# Altium schematic sheet Schematic Prints("All Documents",Physical)
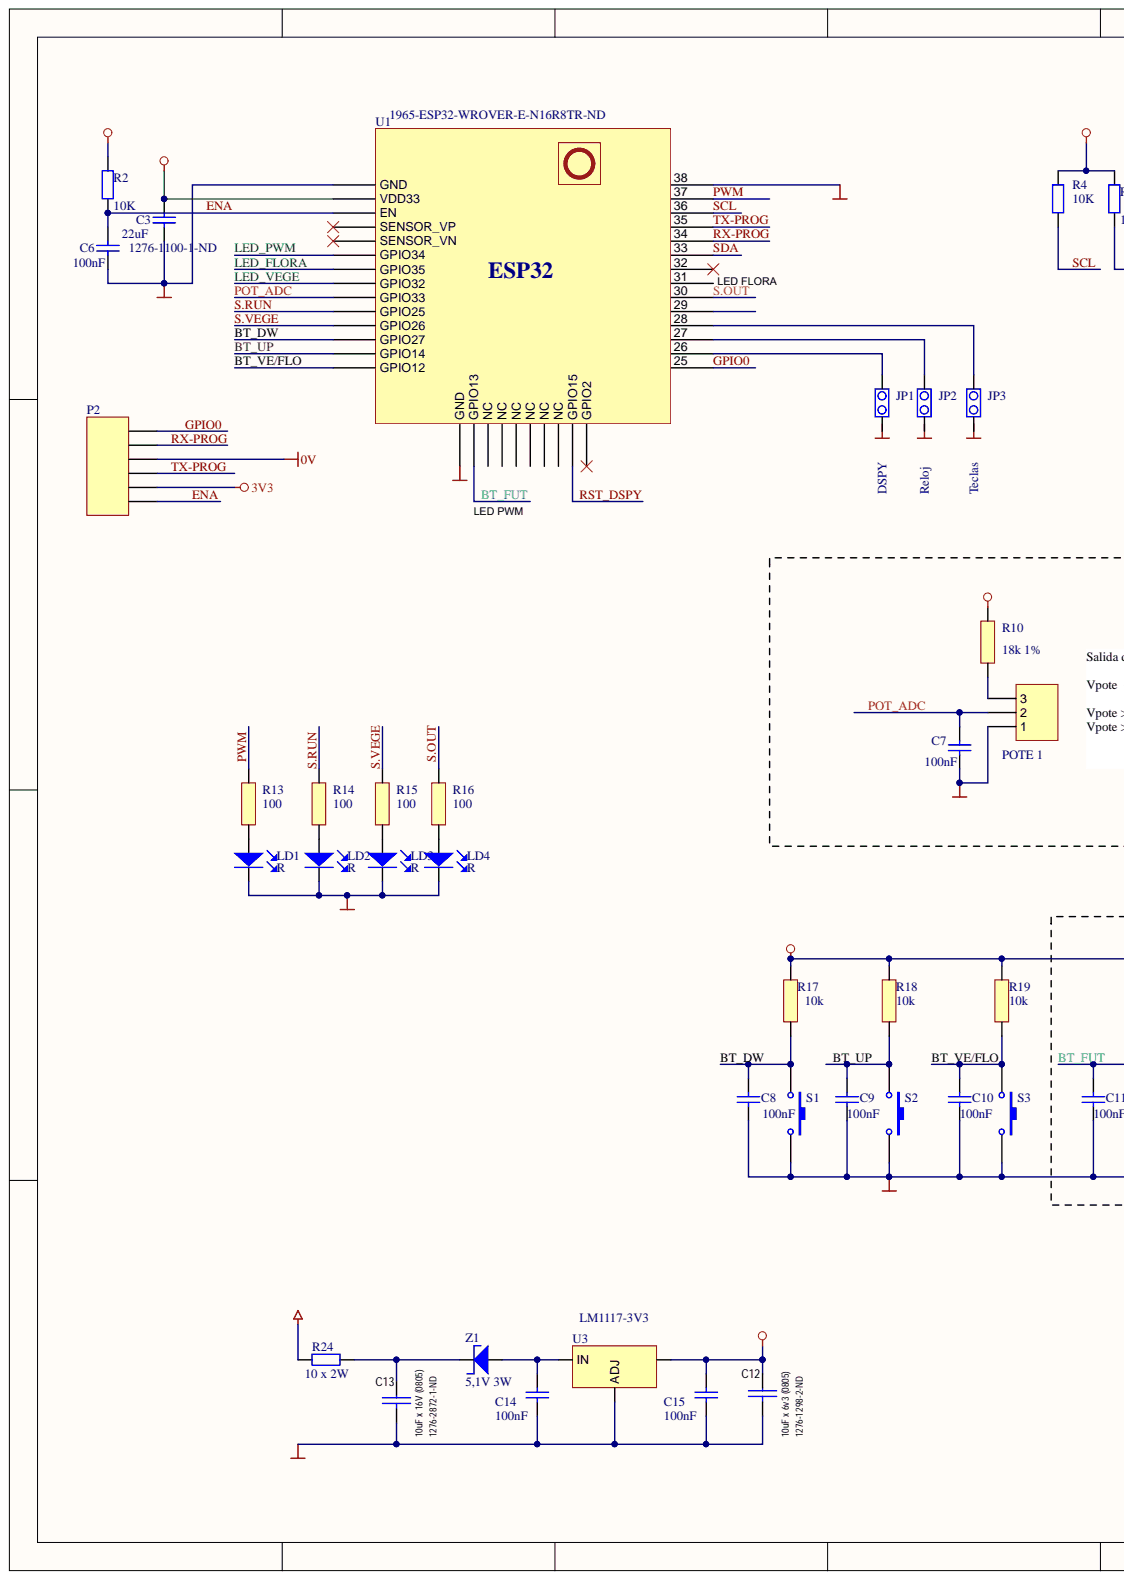
[diagram p0: Schematic Prints("All Documents",Physical), left]
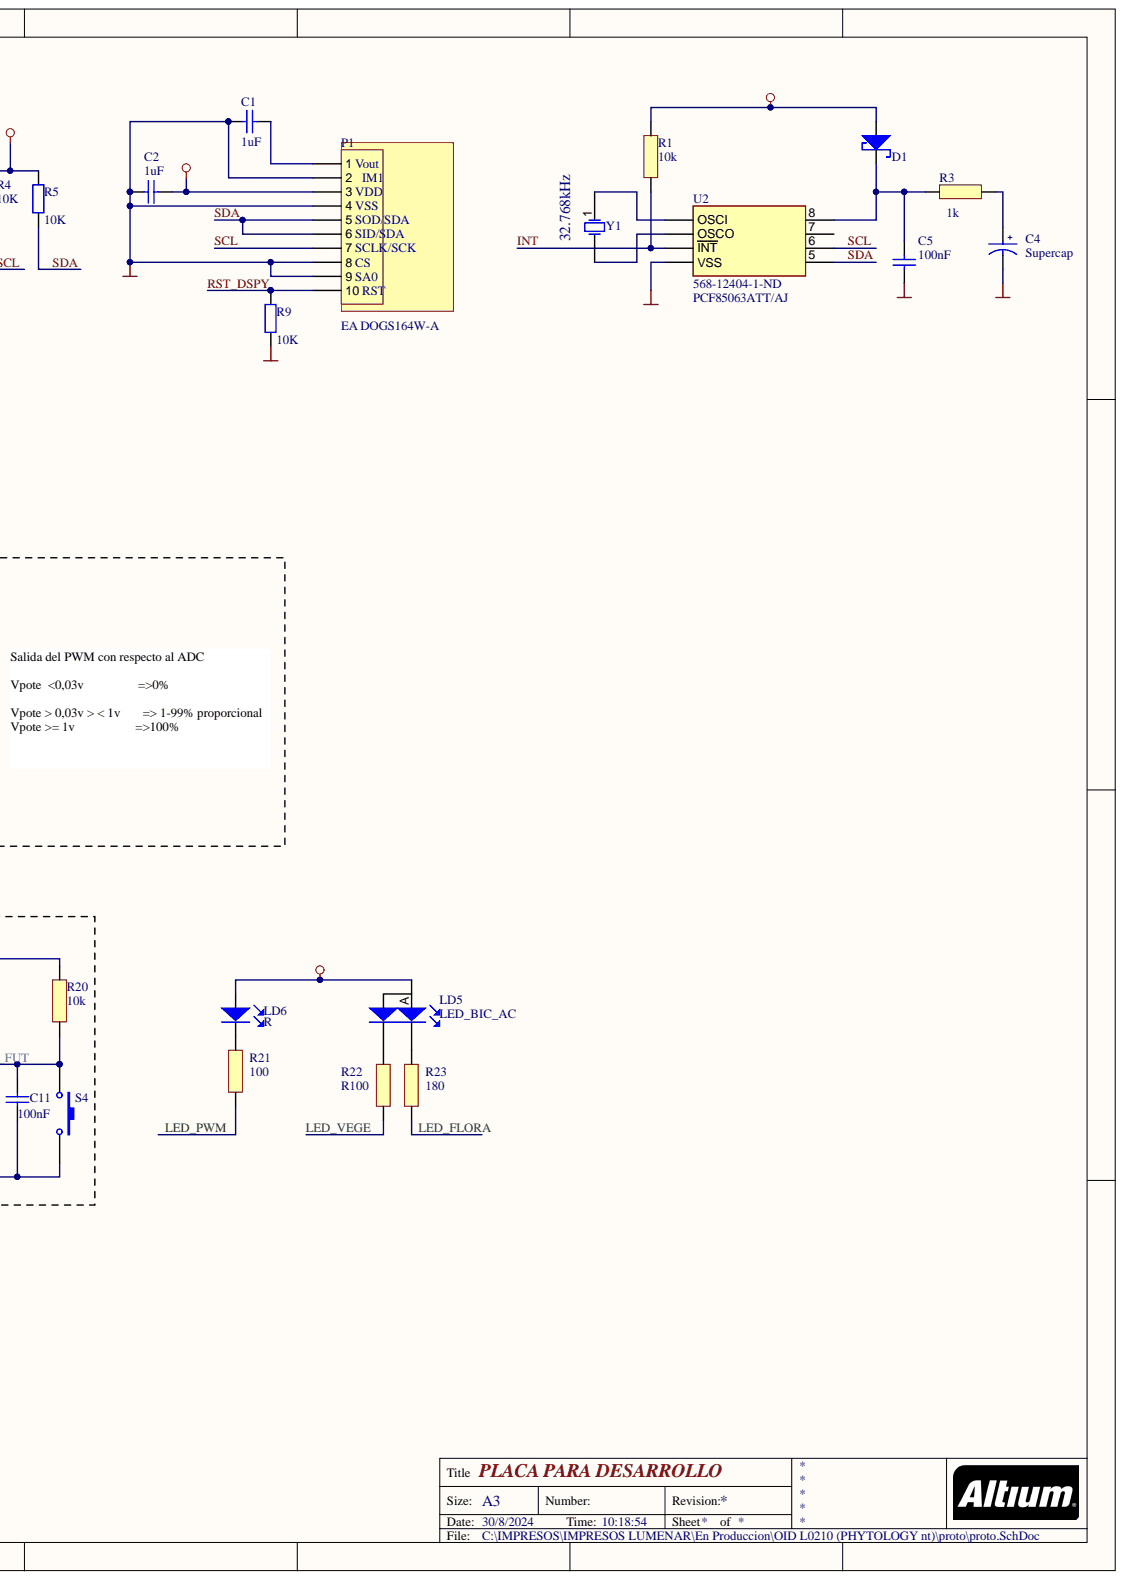
[diagram p1: Schematic Prints("All Documents",Physical), right]

## Parts
C1  value 1uF
C2  value 1uF
C3  1276-1100-1-ND  value 22uF
C4  Supercap
C5  value 100nF
C6  100nF
C7  value 100nF
C8  value 100nF
C9  value 100nF
C10  (no value)
C11  (no value)
C12  10uF x 6v3 (0805)  Supplier Part Number 1: 1276-1298-2-ND  [0805]
C13  10uF x 16V (0805)  Supplier Part Number 1: 1276-2872-1-ND  [0805]
C14  value 100nF
C15  value 100nF
D1  DIODE SCHOTTKY  (value not drawn)
JP1  DSPY
JP2  Reloj
JP3  Teclas
LD1  R
LD2  R
LD3  R
LD4  R
LD5  LED_BIC_AC
LD6  R
P1  EA DOGS164W-A
P2  (no value)
POTE_1  (no value)
R1  10k
R2  value 10K
R3  1k
R4  value 10K
R5  value 10K
R9  value 10K
R10  18k 1%
R13  100
R14  100
R15  100
R16  100
R17  10k
R18  10k
R19  10k
R20  10k
R21  100
R22  R100
R23  180
R24  value 10 x 2W
S1  (no value)
S2  (no value)
S3  (no value)
S4  (no value)
U1  1965-ESP32-WROVER-E-N16R8TR-ND
U2  568-12404-1-ND  Manufacturer_Part_Number: PCF85063ATT/AJ
U3  LM1117-3V3  [LT117-3.3]
Y1  (no value)
Z1  5,1V 3W

## Nets
0V: C1.2 C2.2 C3.1 C4.- C5.1 C6.1 C7.1 C8.1 C9.1 C10.1 C11.1 C12.2 C13.2 C14.1 C15.1 LD1.K LD2.K LD3.K LD4.K P1.2 P1.4 P1.8 P1.9 P2.4 POTE_1.1 S1.2 S2.2 S3.2 S4.2 U1.1 U1.15 U1.38 U2.4 U3.1
3V3: C2.1 C3.2 C12.1 C15.2 D1.A LD5.A LD6.A P1.3 P2.2 R1.1 R2.1 R4.1 R5.1 R9.2 R10.1 R17.1 R18.1 R19.1 R20.1 U1.2 U3.2
+12: R24.1
BT_DW: C8.2 R17.2 S1.1 U1.12
BT_FUT: C11.2 R20.2 S4.1 U1.16
BT_UP: C9.2 R18.2 S2.1 U1.13
BT_VE/FLO: C10.2 R19.2 S3.1 U1.14
ENA: C6.2 P2.1 R2.2 U1.3
GPIO0: P2.6 U1.25
INT: R1.2 U2.3
LED_FLORA: R23.2 U1.7
LED_PWM: R21.2 U1.6
LED_VEGE: R22.2 U1.8
POT_ADC: C7.2 POTE_1.2 U1.9
PWM: R13.1 U1.37
RST_DSPY: P1.10 R9.1 U1.23
RX-PROG: P2.5 U1.34
S.OUT: R16.1 U1.30
S.RUN: R14.1 U1.10
S.VEGE: R15.1 U1.11
SCL: P1.7 R4.2 U1.36 U2.6
SDA: P1.5 P1.6 R5.2 U1.33 U2.5
TX-PROG: P2.3 U1.35
NetC1_1: C1.1 P1.1
NetC4_+: C4.+ R3.1
NetC5_2: C5.2 D1.K R3.2 U2.8
NetC13_1: C13.1 R24.2 Z1.K
NetC14_2: C14.2 U3.3 Z1.A
NetJP1_1: JP1.1 U1.26
NetJP1_2: JP1.2
NetJP2_1: JP2.1 U1.27
NetJP2_2: JP2.2
NetJP3_1: JP3.1 U1.28
NetJP3_2: JP3.2
NetLD1_A: LD1.A R13.2
NetLD2_A: LD2.A R14.2
NetLD3_A: LD3.A R15.2
NetLD4_A: LD4.A R16.2
NetLD5_KR: LD5.KR R23.1
NetLD5_KV: LD5.KV R22.1
NetLD6_K: LD6.K R21.1
NetPOTE 1_3: POTE_1.3 R10.2
NetU1_4: U1.4
NetU1_5: U1.5
NetU1_17: U1.17
NetU1_18: U1.18
NetU1_19: U1.19
NetU1_20: U1.20
NetU1_21: U1.21
NetU1_22: U1.22
NetU1_24: U1.24
NetU1_29: U1.29
NetU1_31: U1.31
NetU1_32: U1.32
NetU2_1: U2.1 Y1.1
NetU2_2: U2.2 Y1.2
NetU2_7: U2.7

## Library data of the parts
C1-C3 C5-C9 C14 C15 = Cap: Library Name: Miscellaneous Devices.IntLib; Component Kind: Standard
  C1 C2 C5 C8 C9: Simulation: CAP; Signal Integrity: Cap; Published: 8-Jun-2000; PackageReference: RAD-0.3; PackageDescription: Radial Cap, Thru-Hole; 2 Leads; 0.3 in Pin Spacing; LatestRevisionNote: Re-released for DXP Platform.; LatestRevisionDate: 17-Jul-2002
  C3: Published: 8-Jun-2000; PackageReference: RAD-0.3; PackageDescription: Radial Cap, Thru-Hole; 2 Leads; 0.3 in Pin Spacing; LatestRevisionNote: Re-released for DXP Platform.; LatestRevisionDate: 17-Jul-2002
  C6: Value: 100nF
  C7 C14 C15: Simulation: CAP; Signal Integrity: Cap
  C1-C3 C5 C8 C9: Publisher: Altium Limited
C4 = CAPACITOR POL: Text Field2: 30; Text Field1: 20; Component Kind: Standard; Library Name: Compo Genericos.SCHLIB
C10 C11 = Cap: Value: 100nF; Simulation: CAP; Signal Integrity: Cap; Published: 8-Jun-2000; PackageReference: RAD-0.3; PackageDescription: Radial Cap, Thru-Hole; 2 Leads; 0.3 in Pin Spacing; Library Name: Miscellaneous Devices.IntLib; LatestRevisionNote: Re-released for DXP Platform.; LatestRevisionDate: 17-Jul-2002; Component Kind: Standard; Publisher: Altium Limited
C12 C13 = CAPACITOR CERAMICO: Text Field2: 30; Text Field1: 20; Supplier 1: Digi-Key; Component Kind: Standard; Library Name: Compo Genericos.SCHLIB
JP1-JP3 = JUMPER2: Description: JUMPER2; Component Kind: Standard; Library Name: Varios.SCHLIB
LD1-LD4 LD6 = LED: Text Field2: 20; Text Field1: 40; Component Kind: Standard; Library Name: Compo Genericos.SCHLIB
P1 = MHDR1X10: Library Name: Miscellaneous Connectors.IntLib; Component Kind: Standard
P2 = Header 6: Header, 6-Pin; Library Name: Miscellaneous Connectors.IntLib; Component Kind: Standard
POTE_1 = Header 3: Signal Integrity: Connector; Library Name: Miscellaneous Connectors.IntLib; LatestRevisionNote: Re-released for DXP Platform.; LatestRevisionDate: 17-Jul-2002; Component Kind: Standard; Publisher: Altium Limited
R1 R3 R10 R13-R23 = R: Text Field2: 30; Text Field1: 20; Component Kind: Standard; Library Name: Compo Genericos.SCHLIB
R2 R4 R5 R9 R24 = Res2: Published: 8-Jun-2000; PackageReference: AXIAL-0.4; PackageDescription: Axial Device, Thru-Hole; 2 Leads; 0.4 in Pin Spacing; Library Name: Miscellaneous Devices.IntLib; LatestRevisionNote: Re-released for DXP Platform.; LatestRevisionDate: 17-Jul-2002; Component Kind: Standard; Publisher: Altium Limited
  R4 R5 R9 R24: Simulation: RESISTOR; Signal Integrity: Res
S1-S4 = SW-PB: Published: 24-Mar-1999; PackageReference: SPST-2; PackageDescription: Switch; 2 Leads; Library Name: Miscellaneous Devices.IntLib; LatestRevisionNote: Re-released for DXP Platform.; LatestRevisionDate: 17-Jul-2002; Component Kind: Standard; Publisher: Altium Limited
U1 = Component_1: Library Name: ESP32-WROOM-32UE.SchLib; Component Kind: Standard
U2 = PCF85063ATT_AJ: Component Kind: Standard
U3 = LM1117: LM1117 - Linear regulator; Library Name: Integrados.SCHLIB; Component Kind: Standard
Y1 = XTAL: Library Name: Miscellaneous Devices.IntLib; Component Kind: Standard
Z1 = DIODE ZENER: Text Field2: 20; Text Field1: 30; Component Kind: Standard; Library Name: Compo Genericos.SCHLIB
D1 = DIODE SCHOTTKY: Component Kind: Standard; Library Name: Compo Genericos.SCHLIB
LD5 = LED_BIC_AC: Component Kind: Standard; Library Name: Compo Genericos.SCHLIB
